# Altium schematic sheet Sensor.SchDoc
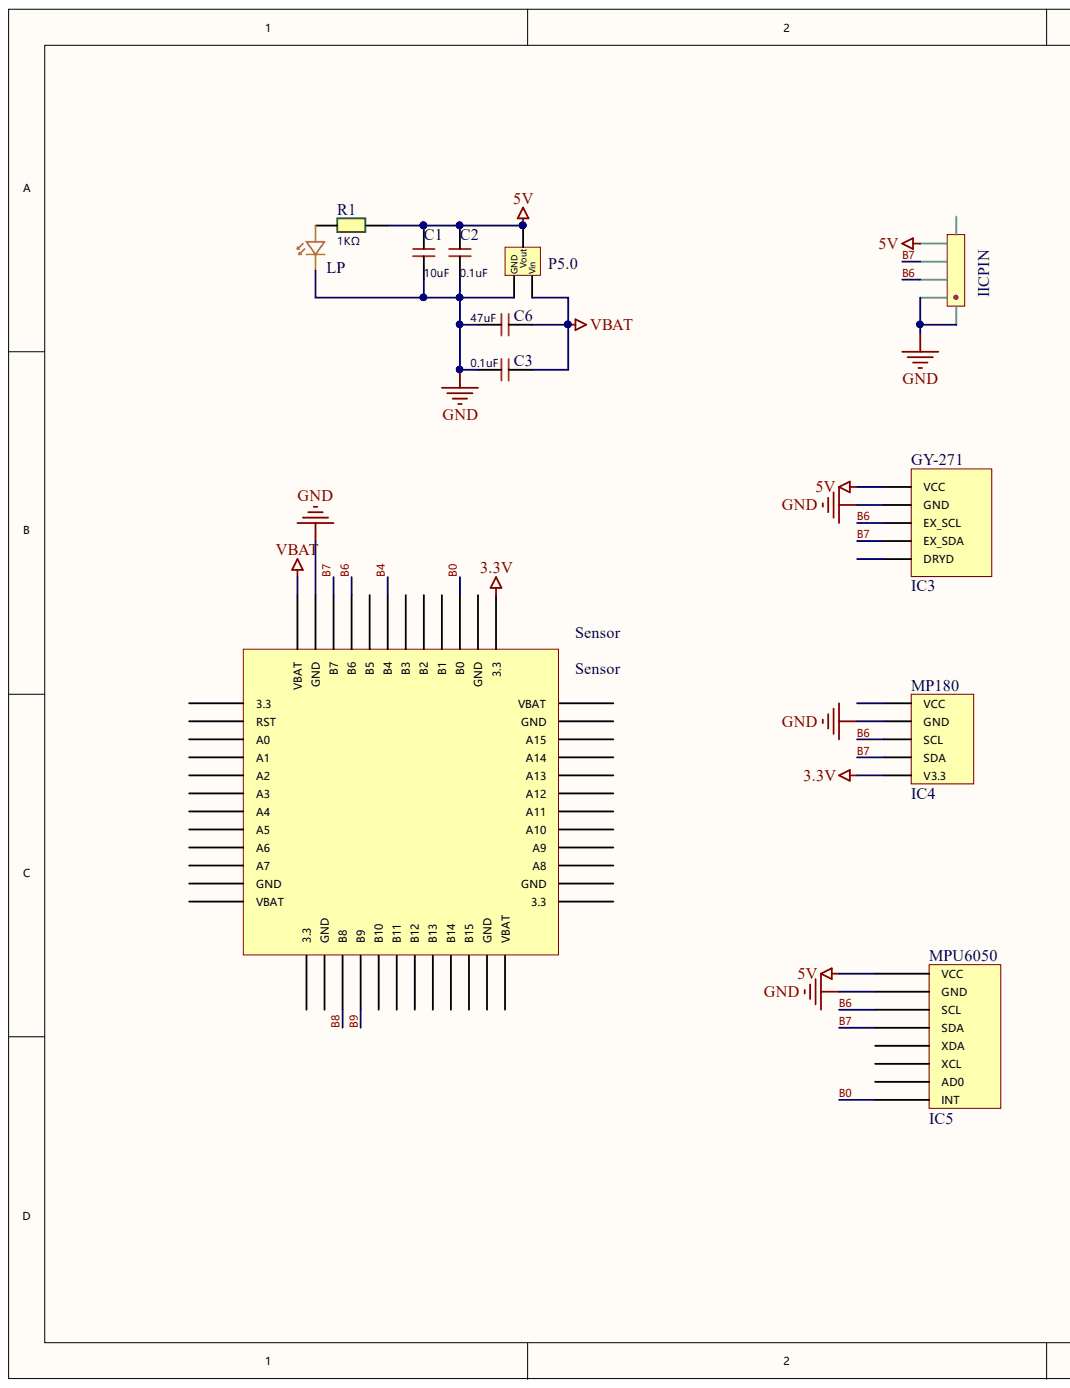
[diagram p0: Sensor.SchDoc, left]
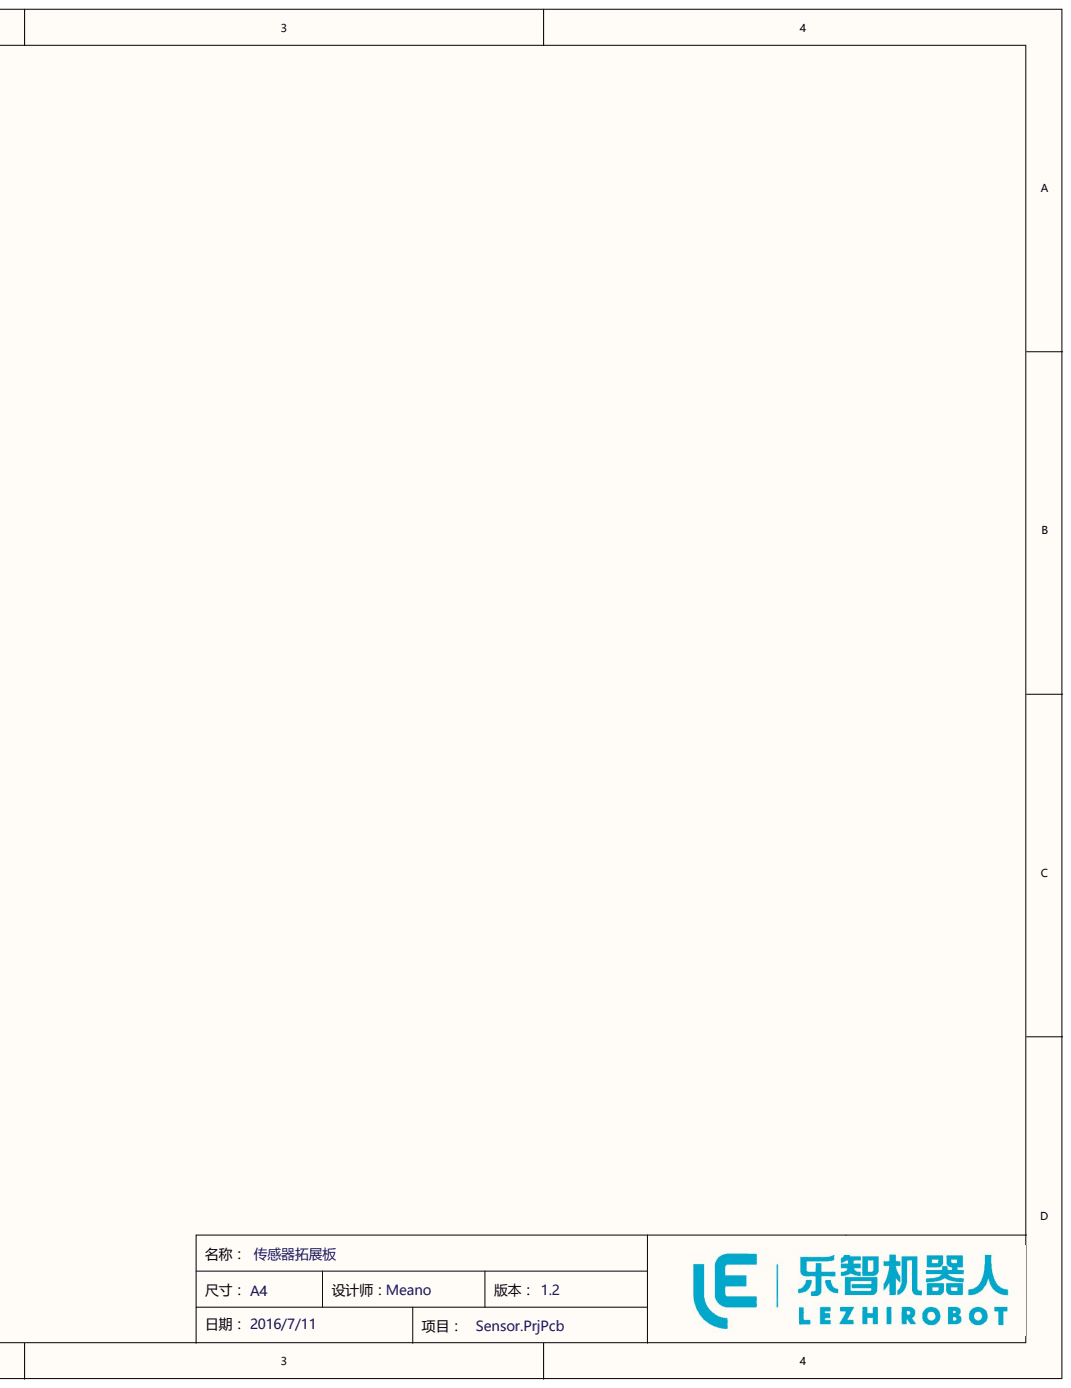
[diagram p1: Sensor.SchDoc, right]

## Parts
C1  value 10uF  [Cap - 0805]  (hidden comment: Cap)
C2  value 0.1uF  [Cap - 0805]  (hidden comment: Cap)
C3  value 0.1uF  [Cap - 0805]  (hidden comment: Cap)
C6  value 47uF  [Cap - 0805]  (hidden comment: Cap)
GY-271  IC3  [GY-271]
IICPIN  SMTPIN-4P  [SMTPIN STAND-4P-1.25]  (value not drawn)
LP  LED Light  [LED - 0805]  (value not drawn)
MP180  IC4  [MP180]
MPU6050  IC5  [MUP6050]
P5.0  PowerIC  [ASM1117]  (value not drawn)
R1  value 1KΩ  [Res - 0805]  (hidden comment: Res)
Sensor  Sensor  [ServoMotor]

## Nets
3.3V: MP180.5(V3.3) Sensor.1
5V: C1.2 C2.2 GY-271.1(VCC) IICPIN.4 MPU6050.1(VCC) P5.0.2 R1.1
GND: C1.1 C2.1 C3.2 C6.2 GY-271.2(GND) IICPIN.0 IICPIN.1 LP.2 MP180.2(GND) MPU6050.2(GND) P5.0.1 Sensor.11
VBAT: C3.1 C6.1 P5.0.3 Sensor.12
B0: MPU6050.8(INT) Sensor.39(B0)
B4: Sensor.43(B4)
B6: GY-271.3(EX_SCL) IICPIN.2 MP180.3(SCL) MPU6050.3(SCL) Sensor.45(B6)
B7: GY-271.4(EX_SDA) IICPIN.3 MP180.4(SDA) MPU6050.4(SDA) Sensor.46(B7)
B8: Sensor.15(B8)
B9: Sensor.16(B9)
NetLP_1: LP.1 R1.2

Not connected: GY-271 5(DRYD); MP180 1(VCC); MPU6050 5(XDA) 6(XCL) 7(AD0); Sensor 2(GND) 3(A0) 4(A1) 5(A2) 6(A3) 7(A4) 8(A5) 9(A6) 10(A7) 17(B10) 18(B11) 19(B12) 20(B13) 21(B14) 22(B15) 27(A8) 28(A9) 29(A10) 30(A11) 31(A12) 32(A13) 33(A14) 34(A15) 40(B1) 41(B2) 42(B3) 44(B5) 47(RST)

## Library data of the parts
C1-C3 C6 = Cap: Cap Normal; Component Kind: Standard; Library Name: SmartTuna-ESchLib.SchLib
GY-271 = GY-271: Component Kind: Standard; Library Name: SmartTuna-ESchLib.SchLib
LP = LED: Component Kind: Standard; Library Name: SmartTuna-ESchLib.SchLib
MP180 = MP180: Component Kind: Standard; Library Name: SmartTuna-ESchLib.SchLib
MPU6050 = MPU6050: Component Kind: Standard; Library Name: SmartTuna-ESchLib.SchLib
P5.0 = ASM1117 - 5.0: PowerControl; Component Kind: Standard; Library Name: SmartTuna-ESchLib.SchLib
Sensor = ServoMotor: Component Kind: Standard; Library Name: SmartTuna-ESchLib.SchLib
IICPIN = SMTPIN-4P: Component Kind: Standard; Description: SMTPIN-4P; Library Name: SmartTuna-ESchLib.SchLib
R1 = Res: Component Kind: Standard; Description: Res; Library Name: SmartTuna-ESchLib.SchLib
